# Altium schematic sheet Gatekeeper_sheet4_power_supply_V2.2.SchDoc(Gatekeeper_sheet4_power_supply_V2.2)
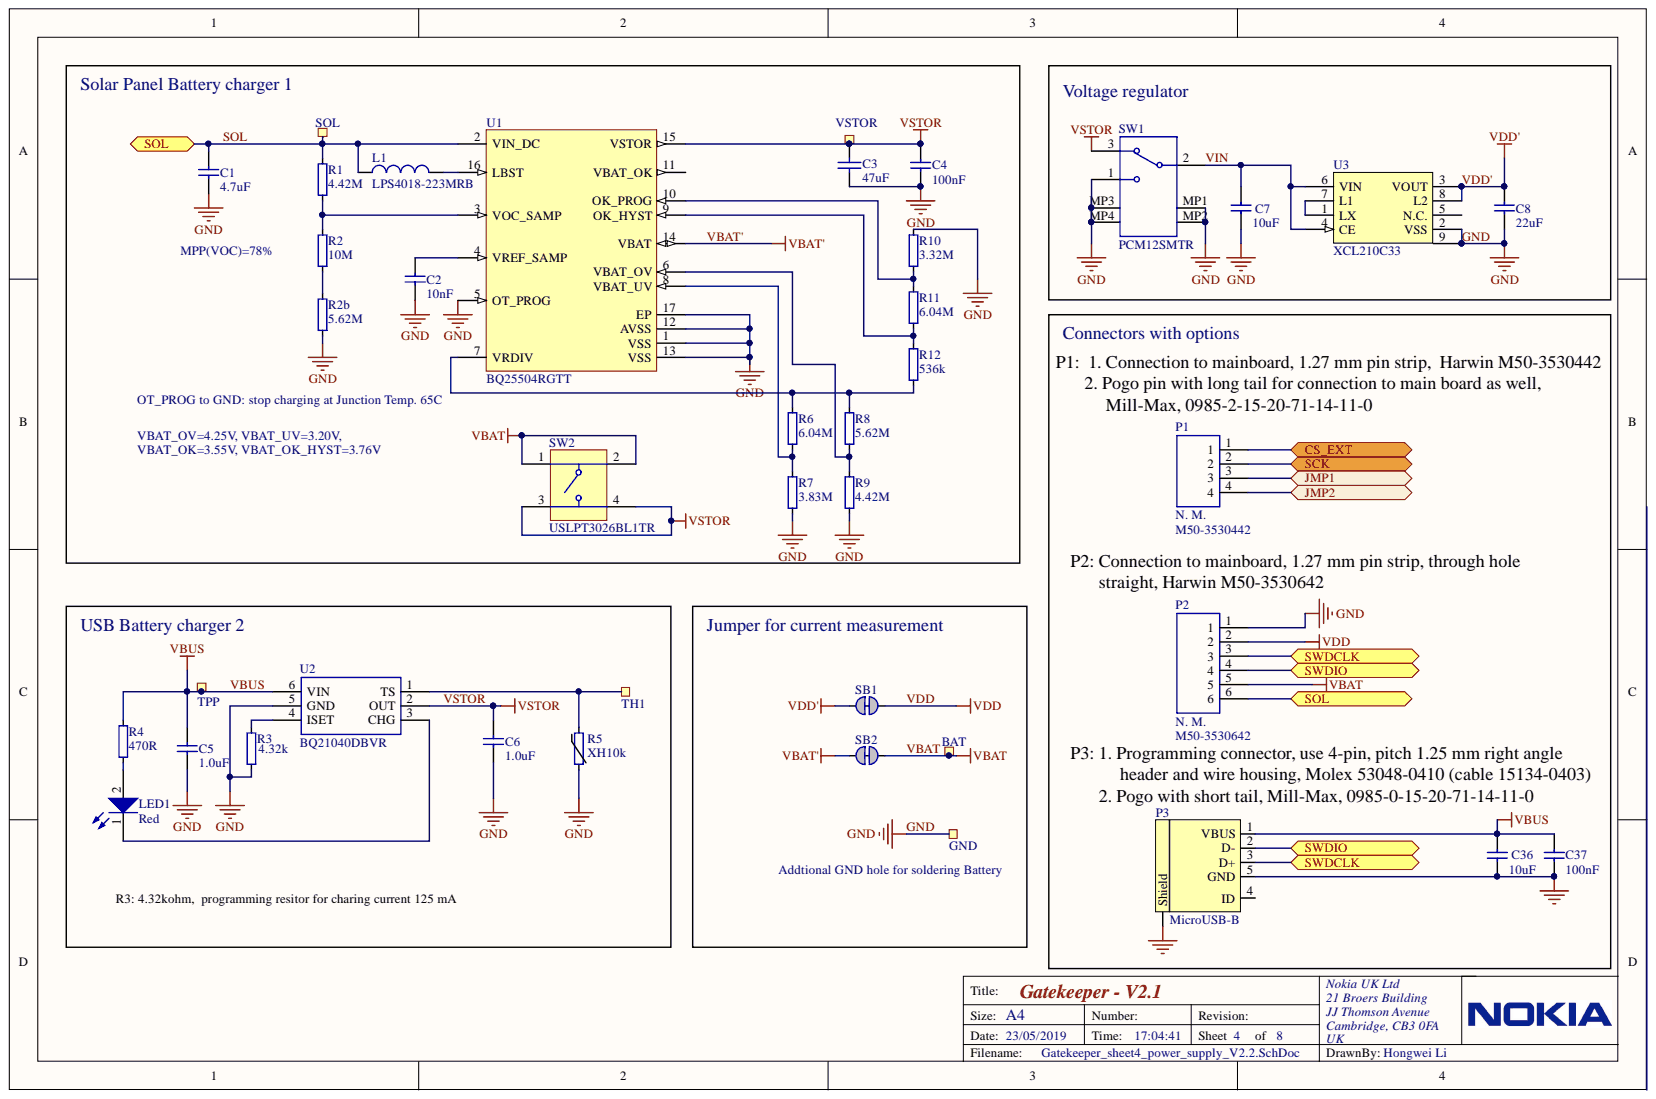
[diagram p0: Gatekeeper_sheet4_power_supply_V2.2.SchDoc]

## Parts
BAT  Comment  [TH_SINGLE]  (value not drawn)  (no BOM)
C1  4.7uF  [CAPC1608X06L]
C2  10nF  [CAPC1005X04L]
C3  47uF  [CAPC2013X145X20NL20]
C4  100nF  [CAPC1005X04L]
C5  1.0uF  [CAPC1608X06L]
C6  1.0uF  [CAPC1608X06L]
C7  10uF  [CAPC1608X06L]
C8  22uF  [CAPC1608X06L]
C36  10uF  [CAPC1608X06L]
C37  100nF  [CAPC1005X04L]
GND  Comment  [TH_SINGLE_SQUARE]  (value not drawn)  (no BOM)
L1  LPS4018-223MRB  [COIL-LPS4018_V]
LED1  Red  [0603_LED]
P1  N. M.  value M50-3530442  [HDRV4W45P0X127_1X4_508X210X430P]  (no BOM)
P2  N. M.  value M50-3530642  [HDRV6W45P0X127_1X6_762X210X430P]  (no BOM)
P3  MicroUSB-B  [USB-Micro-B-RA-SMD-PiP]
R1  4.42M  [RESC1005X04L]
R2  10M  [RESC1005X04L]
R2b  5.62M  [RESC1005X04L]
R3  4.32k  [RESC1005X04L]
R4  470R  [RESC1005X04L]
R5  XH10k  [RESC1005X04L_overlay2]
R6  6.04M  [RESC1005X04L]
R7  3.83M  [RESC1005X04L]
R8  5.62M  [RESC1005X04L]
R9  4.42M  [RESC1005X04L]
R10  3.32M  [RESC1005X04L]
R11  6.04M  [RESC1005X04L]
R12  536k  [RESC1005X04L]
SB1  Solderbridge  [Solderbridge_Shorted_Big]  (value not drawn)
SB2  Solderbridge  [Solderbridge_Shorted_Big]  (value not drawn)
SOL  Comment  [List_1x1_small-NC]  (value not drawn)  (no BOM)
SW1  PCM12SMTR  [SWITCH_CKCP-PCM12SMTR_V]
SW2  USLPT3026BL1TR  [Switch_USLPT3026EL1TR]
TH1  =Value  [TP_SMD_Ø1.2mm]  (no BOM)
TPP  VBUS  [TP_SMD_Ø1.5mm]  (no BOM)
U1  BQ25504RGTT  [RGT0016C_V]
U2  BQ21040DBVR  [SOT95P280X145-6N]
U3  XCL210C33  [CL-2025]
VSTOR  =Value  [List_1x1_small-NC]  (no BOM)

## Ports
CS_EXT, JMP1, JMP2, SCK, SOL, SWDCLK, SWDIO

## Nets
CS_EXT: P1.1 ; port CS_EXT
GND: C1.2 C2.2 C3.1 C4.2 C5.1 C6.1 C7.1 C8.1 C36.1 C37.2 GND.1 P2.1 P3.5(GND) P3.6(Shield) R2b.2 R3.2 R5.2 R7.2 R9.2 R10.2 SW1.1 SW1.MP1 SW1.MP2 SW1.MP3 SW1.MP4 U1.1(VSS) U1.5(OT_PROG) U1.12(AVSS) U1.13(VSS) U1.17(EP) U2.5(GND) U3.2(VSS) U3.9
JMP1: P1.3 ; port JMP1
JMP2: P1.4 ; port JMP2
SCK: P1.2 ; port SCK
SOL: C1.1 L1.1 P2.6 R1.1 SOL.1 U1.2(VIN_DC) ; port SOL
SWDCLK: P2.3 P3.3(D+) ; port SWDCLK
SWDIO: P2.4 P3.2(D-) ; port SWDIO
VBAT: BAT.1 P2.5 SB2.1 SW2.1 SW2.2
VBAT': SB2.2 U1.14(VBAT)
VBUS: C5.2 C36.2 C37.1 P3.1(VBUS) R4.1 TPP.1 U2.6(VIN)
VDD: P2.2 SB1.1
VDD': C8.2 SB1.2 U3.3(VOUT) U3.8(L2)
VIN: C7.2 SW1.2 U3.4(CE) U3.6(VIN)
VSTOR: C3.2 C4.1 C6.2 SW1.3 SW2.3 SW2.4 U1.15(VSTOR) U2.2(OUT) VSTOR.1
NetC2_1: C2.1 U1.4(VREF_SAMP)
NetL1_2: L1.2 U1.16(LBST)
NetLED1_1: LED1.1 U2.3(CHG)
NetLED1_2: LED1.2 R4.2
NetR1_2: R1.2 R2.1 U1.3(VOC_SAMP)
NetR2_2: R2.2 R2b.1
NetR3_1: R3.1 U2.4(ISET)
NetR5_1: R5.1 TH1.1 U2.1(TS)
NetR6_1: R6.1 R8.1 R12.2 U1.7(VRDIV)
NetR6_2: R6.2 R7.1 U1.8(VBAT_UV)
NetR8_2: R8.2 R9.1 U1.6(VBAT_OV)
NetR10_1: R10.1 R11.1 U1.10(OK_PROG)
NetR11_2: R11.2 R12.1 U1.9(OK_HYST)
NetU3_1: U3.1(LX) U3.7(L1)
N00082:

Not connected: P3 4(ID); U1 11(VBAT_OK); U3 5(N.C.)

## Library data of the parts
BAT GND SOL TH1 TPP VSTOR = TP_SMD: SMD testpad of various size; Library Name: Gatekeeper_V2.1.SchLib
C1 = CAP_0603: Capacitor, 0603, X5R, ±10%; Part Number: C0603-4µ7; Manufacturer Part Number: GCJ188C70J475KE02D; Manufacturer: Murata Electronics; 2nd source part number: 490-16480-1-ND; Note: 6.3V; Value: 4.7uF; Supplier part number: 81-GCJ188C70J475KE2D; Supplier: Mouser; Pin Count: 2; Footprint Doc: 0603; Component Kind: Standard; 2nd source Supplier: DigiKey; Library Name: Gatekeeper_V2.1.SCHLIB
C2 = CAP_0402_1: Capacitor, 0402, X7R, ±5%; Part Number: C0402; Manufacturer Part Number: CC402JRX7R9BB103; Manufacturer: Yageo; 2nd source part number: 311-3339-1-ND; Note: 50V; Value: 10nF; Supplier part number: 603-CC402JRX7R9BB103; Supplier: Mouser; Pin Count: 2; Footprint Doc: 0402; Datasheet: https://www.mouser.co.uk/datasheet/2/447/UPY-GPHC_X7R_6.3V-to-50V_18-1154002.pdf; Component Kind: Standard; 2nd source Supplier: DigiKey; Library Name: Gatekeeper_V2.1.SCHLIB
C3 = CMP-2000-05451-1: Chip Capacitor, 47 uF, +/- 20%, 10 V, -55 to 85 degC, 0805 (2012 Metric), RoHS, Tape and Reel; PCN Part Number: MLCCPartNumberChange30/Nov/2012; Manufacturer: TDK; Manufacture Part Number: C2012X5r1A476M125AC; Voltage - Rated: 10V; Temperature Coefficient: X5R; Product Training Modules: HighCapacitanceReplacementCSeriesGeneralApplicationsSEAT,CCV,andTVCLDesignToolsGuidetoReplacinganElectrolyticCapacitorwithanMLCC; Other Names: 445-8239-1; Online Catalog: CSeries,GeneralPurpose; Kits: 445-9015-KIT-ND-KITMLCC1000PF-47UF080510-50V445-9003-KIT-ND-KITCAPCERHICAPMLCCSMD; Catalog Drawings: CSERIES_2012-0805_1.45; Capacitance: 47µF; Value: 47uF; Tolerance: ±20%; Thickness (Max): 0.057"(1.45mm); Standard Package: 1; Size / Dimension: 0.079"Lx0.049"W(2.00mmx1.25mm); Series: C; RoHS Information: RoHSCertificate-MLCC; Ratings: -; Packaging: CutTape(CT); Package URL: https://product.tdk.com/info/zh/catalog/datasheets/mlcc_commercial_general_zh.pdf; Package / Case: 0805(2012Metric); Operating Temperature: -55degC~85degC; Mounting Type: SurfaceMount,MLCC; Manufacturer URL: http://www.tdk.com/; Lead Style: -; Lead Spacing: -; Height - Seated (Max): -; Features: -; Family: CeramicCapacitors; Datasheet Version: Mar-15; Datasheet URL: http://download.siliconexpert.com/pdfs/2015/4/21/15/54/45/779/tdk_/manual/37024850441143360mlcc_commercial_general_en.pdf; Component Kind: Standard; Category: Capacitors; Applications: GeneralPurpose; Library Name: Gatekeeper_V2.1.SchLib
C4 C37 = (no symbol name): Capacitor, 0402, X7R, ±10%; Part Number: C0402; Manufacturer Part Number: 885012205037; Manufacturer: Wurth Electronics; 2nd source part number: 81-GCM155R71C104KA5D; Note: 16V; Value: 100nF; Supplier part number: 710-885012205037; Supplier: Mouser; Pin Count: 2; Footprint Doc: 0402; Datasheet: https://www.mouser.co.uk/datasheet/2/445/885012205037-554188.pdf; Component Kind: Standard; 2nd source Supplier: Mouser; Library Name: Gatekeeper.SCHLIB
C5 C6 = (no symbol name): Capacitor, 0603, X7R, ±10%; Part Number: C0603-1µ0; Manufacturer Part Number: JMK107B7105KA-T; Manufacturer: Taiyo Yuden; 2nd source part number: 710-885012206002; Note: 6.3V; Value: 1.0uF; Supplier part number: 963-JMK107B7105KA-T; Supplier: Mouser; Pin Count: 2; Footprint Doc: 0603; Component Kind: Standard; 2nd source Supplier: Mouser; Library Name: Gatekeeper.SCHLIB
C7 C36 = (no symbol name): Capacitor, 0603, X5R, ±10%; Manufacturer Part Number: C1608X5R1A106K080AC; Manufacturer: TDK; 2nd source part number: 81-GRM188R61A106KE9J; Note: 10V; Value: 10uF; Supplier part number: 810-C1608X5R1A106K; Supplier: Mouser; Pin Count: 2; Footprint Doc: 0603; Datasheet: https://www.mouser.co.uk/datasheet/2/400/lcc_commercial_general_en-837201.pdf; Component Kind: Standard; 2nd source Supplier: Mouser; Library Name: Gatekeeper.SCHLIB
C8 = (no symbol name): Capacitor, 0603, X5R, ±20%; Manufacturer Part Number: C1608X5R1A226M080AC; Manufacturer: TDK; 2nd source part number: 81-GRM188R61A226ME5J; Note: 10V; Value: 22uF; Supplier part number: 810-C1608X5R1A226M; Supplier: Mouser; Pin Count: 2; Footprint Doc: 0603; Datasheet: https://www.mouser.co.uk/datasheet/2/400/lcc_commercial_general_en-837201.pdf; Component Kind: Standard; 2nd source Supplier: Mouser; Library Name: Gatekeeper.SCHLIB
L1 = LPS4018-223MRB: Shielded Power Inductor, 22 uH, +/- 20%, 360 mOhm, -40 to 85 degC, 2-Pin SMD, RoHS, Tape and Reel; Manufacturer: Coilcraft; Unit Weight: 0.001411oz; Test Frequency: 100kHz; Self Resonant Frequency: 26MHz; Qualification: AEC-Q200; Maximum DC Resistance: 360mOhms; Maximum DC Current: 830mA; Inductance: 22uH; Core Material: Ferrite; Width: 3mm; Type: ShieldedPowerInductor; Tolerance: 20%; Termination Style: SMD/SMT; Shielding: Shielded; Series: LPS3015; RoHS: True; Product Category: FixedInductors; Product: PowerInductors; Packaging: CutTape; Package Reference: LPS4018; Package Description: 2-Pin Surfave Mount Device, Body 2.95 x 2.95 mm; Operating Temperature Range: -40Cto+85C; Mounting Technology: SurfaceMount; Mounting Style: SMD/SMT; Manufacturer URL: http://www.coilcraft.com/; Manufacture Part Number: LPS4018-223MRB; Length: 4.0mm; Height: 1.7mm; Factory Pack Quantity: 1; Datasheet URL: http://www.coilcraft.com/pdfs/lps4018.pdf; Component Kind: Standard; Brand: Coilcraft; Library Name: Gatekeeper_V2.1.SchLib
LED1 = CMP-1426-00001-1: SMD mono-color Chip LED, WL-SMCW, Red; Manufacturer Part Number: 150060RS75000; Manufacturer: Wuerth Elektronik; Supplier Part Number: 710-150060RS75000; Supplier: Mouser; PackageReference: 0603_A; Library Name: Integrated_Library - 0603_LED.IntLib; ComponentLink2URL: http://katalog.we-online.com/led/datasheet/150060RS75000.pdf; ComponentLink2Description: Datasheet; ComponentLink1URL: http://www.we-online.com; ComponentLink1Description: Manufacturer URL; Component Kind: Standard; Color: Red
P1 = M50-3530442: Headers & Wire Housings 4 WAY SIL VERT PC TAIL P/HDR; Manufacturer: HARWIN; Geometry.Height: 4.3mm; Assembly: Not Mounted; Supplier Part Number: 855-M50-3530442; Supplier: Mouser; Mouser Price/Stock: https://www.mouser.com/Search/Refine.aspx?Keyword=855-M50-3530442; Mouser Part Number: 855-M50-3530442; Manufacturer Part Number: M50-3530442; Library Name: M50-3530x42.SchLib; Datasheet Link: https://componentsearchengine.com/Datasheets/1/M50-3530442.pdf
P2 = M50-3530642: Headers & Wire Housings 6 WAY SIL VERT PC TAIL P/HDR; Manufacturer: HARWIN; Geometry.Height: 4.3mm; Assembly: Not Mounted; Supplier Part Number: 855-M50-3530642; Supplier: Mouser; Mouser Price/Stock: https://www.mouser.com/Search/Refine.aspx?Keyword=855-M50-3530642; Mouser Part Number: 855-M50-3530642; Manufacturer Part Number: M50-3530642; Library Name: M50-3530x42.SchLib; Datasheet Link: https://componentsearchengine.com/Datasheets/1/M50-3530642.pdf
P3 = USB-Micro-B-RA-SMD-PiP: MicroUSB-B-SMT; Manufacturer Part Number: AJU55-BS2111-L; Manufacturer: Allen Creations Corp.; 2nd source part number: 10118193-0001LF; Value: MicroUSB-B; Supplier part number: 649-10118193-0001LF; Supplier: Mouser; Pin Count: 5; Part Number: USB-Micro-B-RA-SMD-PiP; LatestRevisionDate: 2016-04-21; Footprint Doc: USB-Micro-B-RA-SMD-PiP; Datasheet: https://cdn.amphenol-icc.com/media/wysiwyg/files/documentation/datasheet/inputoutput/io_usb_micro.pdf; Component Kind: Standard; 2nd source Supplier: Digi-Key; Library Name: Gatekeeper_8D_V2.1.PcbLib
R1 R9 = (no symbol name): Resistor, 0402, ±1%, 0.063W; Part Number: R0402; Manufacturer Part Number: RC0402FR-074M42L; Manufacturer: Yageo; Value Ohm: 4.42e6; Note: Chip Res 1%; Value: 4.42M; Supplier part number: 603-RC0402FR-074M42L; Supplier: Mouser; Pin Count: 2; Footprint Doc: 0402; Component Kind: Standard; Library Name: Gatekeeper.SCHLIB
R2 = (no symbol name): Resistor, 0402, ±1%, 0.063W; Part Number: R0402; Manufacturer Part Number: RC0402FR-0710ML; Manufacturer: Yageo; 2nd source part number: DigiKey; Value Ohm: 10e6; Note: Chip Res 1%; Value: 10M; Supplier part number: 603-RC0402FR-0710ML; Supplier: Mouser; Pin Count: 2; Footprint Doc: 0402; Datasheet: https://www.mouser.co.uk/datasheet/2/447/PYu-RC_Group_51_RoHS_L_10-1527934.pdf; Component Kind: Standard; 2nd source Supplier: 311-10.0MLRCT-ND; Library Name: Gatekeeper.SCHLIB
R2b R8 = (no symbol name): Resistor, 0402, ±1%, 0.063W; Part Number: R0402; Manufacturer Part Number: RC0402FR-075M62L; Manufacturer: Yageo; 2nd source part number: 71-CRCW04025M62FKED; Value Ohm: 5.62e6; Note: Chip Res 1%; Value: 5.62M; Supplier part number: 603-RC0402FR-075M62L; Supplier: Mouser; Pin Count: 2; Footprint Doc: 0402; Datasheet: https://www.mouser.co.uk/datasheet/2/447/PYu-RC_Group_51_RoHS_L_10-1527934.pdf; Component Kind: Standard; 2nd source Supplier: Mouser; Library Name: Gatekeeper.SCHLIB
R3 = RES_0402: Resistor, 0402, ±1%, 0.063W; Part Number: R0402; Manufacturer Part Number: CRCW04024K32FKED; Manufacturer: Vishay; Value Ohm: 4320; Note: Chip Res 1%; Value: 4.32k; Supplier part number: 71-CRCW0402-4.32K-E3; Supplier: Mouser; Pin Count: 2; Footprint Doc: 0402; Datasheet: https://www.mouser.co.uk/datasheet/2/427/dcrcwe3-45987.pdf; Component Kind: Standard; Library Name: Gatekeeper_V2.1.SCHLIB
R4 = RES_1: Resistor, ±1%, 0.063W; Part number IPS: 00000007975; Part Number: R0402-470; Manufacturer Part Number: CRCW0402470RFKEDHP; Manufacturer: Vishay; 2nd source part number: 1738845; Value Ohm: 470; Note: Chip Res 1%; Value: 470R; Supplier part number: 71-CRCW0402470RFKEDH; Supplier: Mouser; Published: 2009-05-08; Pin Count: 2; Footprint Doc: 0402; Datasheet: https://www.mouser.co.uk/datasheet/2/427/crcwhpe3-524687.pdf; Component Kind: Standard; 2nd source Supplier: Farnell; Library Name: Gatekeeper_V2.1.SCHLIB
R5 = NCP15XH103F03RC: THERMISTOR 10K OHM NTC 0402 SMD; Part number IPS: 00000007808; Manufacturer: Murata; 3rd source part number: 490-4801-1-ND; 2nd source part number: 2456121; Value Ohm: 10000; Note: Chip Res 1%; Database Table Name: Resistors$; Value: XH10k; Supplier Part Number: 81-NCP15XH103F03RC; Supplier: Mouser; Pin Count: 2; Part Number: NCP15XH103F03RC; Manufacturer Part Number: NCP15XH103F03RC; Library Name: Nordic Passive DB.DbLib; LatestRevisionDate: 2015-03-25; Footprint Doc: 0402; Datasheet: https://www.mouser.co.uk/datasheet/2/281/r44e-522712.pdf; Component Kind: Standard; 3rd source Supplier: Digi-Key; 2nd source Supplier: Farnell
R6 R11 = (no symbol name): Resistor, 0402, ±1%, 0.063W; Part Number: R0402; Manufacturer Part Number: RC0402FR-076M04L; Manufacturer: Yageo; 2nd source part number: 71-CRCW04026M04FKED; Value Ohm: 6.04e6; Note: Chip Res 1%; Value: 6.04M; Supplier part number: 603-RC0402FR-076M04L; Supplier: Mouser; Pin Count: 2; Footprint Doc: 0402; Datasheet: https://www.mouser.co.uk/datasheet/2/447/PYu-RC_Group_51_RoHS_L_10-1527934.pdf; Component Kind: Standard; 2nd source Supplier: Mouser; Library Name: Gatekeeper.SCHLIB
R7 = (no symbol name): Resistor, 0402, ±1%, 0.063W; Part Number: R0402; Manufacturer Part Number: CRCW04023M83FKED; Manufacturer: Vishay; 2nd source part number: 2316678; Value Ohm: 3.83e6; Note: Chip Res 1%; Value: 3.83M; Supplier part number: 71-CRCW04023M83FKED; Supplier: Mouser; Pin Count: 2; Footprint Doc: 0402; Datasheet: https://www.mouser.co.uk/datasheet/2/427/dcrcwe3-45987.pdf; Component Kind: Standard; 2nd source Supplier: Farnell; Library Name: Gatekeeper.SCHLIB
R10 = (no symbol name): Resistor, 0402, ±1%, 0.063W; Part Number: R0402; Manufacturer Part Number: RC0402FR-073M32L; Manufacturer: Yageo; 2nd source part number: 71-CRCW04023M32FKED; Value Ohm: 3.32e6; Note: Chip Res 1%; Value: 3.32M; Supplier part number: 603-RC0402FR-073M32L; Supplier: Mouser; Pin Count: 2; Footprint Doc: 0402; Datasheet: https://www.mouser.co.uk/datasheet/2/447/PYu-RC_Group_51_RoHS_L_10-1527934.pdf; Component Kind: Standard; 2nd source Supplier: Mouser; Library Name: Gatekeeper.SCHLIB
R12 = (no symbol name): Resistor, 0402, ±1%, 0.063W; Part Number: R0402; Manufacturer Part Number: ERJ-2RKF5363X; Manufacturer: Panasonic; 2nd source part number: 2302922; Value Ohm: 536000; Note: Chip Res 1%; Value: 536k; Supplier part number: 667-ERJ-2RKF5363X; Supplier: Mouser; Pin Count: 2; Footprint Doc: 0402; Datasheet: https://www.mouser.co.uk/datasheet/2/315/AOA0000C304-1149620.pdf; Component Kind: Standard; 2nd source Supplier: Farnell; Library Name: Gatekeeper.SCHLIB
SB1 SB2 = SOLDERBRIDGE_SHORTED: Shorted Solderbridge; Part Number: Solderbridge Shorted; Artikkelnr Simpro: N.A.; Value: Solderbridge; Published: 2012-08-07; Pin Count: 2; LatestRevisionDate: 2012-08-07; Footprint Doc: Solderbridge_Shorted; Component Kind: Net Tie; Artikkelnr Noca: N.A.; Publisher: Nordic Semiconductor; Library Name: Gatekeeper_V2.1.SCHLIB
SW1 = CMP-2000-06884-1: Ultraminiature Slide Switch, SPDT, 0.3 A, 6 V, -20 to 85 degC, 3-Pin SMD, RoHS, Tape and Reel; Manufacturer: C&K Components; Switch Operation: On-On; Contact Current-Max: 0.3A; Termination Style: RightAngle/SurfaceMount; Package Reference: PCM12SMTR; Package Description: 3-Pin Surface Mount Device, Body 6.7 x 2.6 mm; Mounting Technology: SurfaceMount; Manufacturer URL: http://www.ckswitches.com/; Datasheet Version: 02/2016; Datasheet URL: http://www.ck-components.com/media/1424/pcm.pdf; Contact Material: SilverOverCopper; Component Kind: Standard; Circuitry: SPDT; Library Name: Gatekeeper_V2.1.SCHLIB
SW2 = PushButton_LowProfile: Low-Profile Tactile Switch, SPST, 50 mA, 12 VDC, -40 to 85 degC, 4-Pin SMD, RoHS, Tape and Reel; Manufacturer: TE Connectivity; Switch Configuration: OFF - (ON); Operating Force: 100gf; Minimum Insulation Resistance: >=50MOhm; Mechanical Life: 100000Cycles; Maximum DC Voltage Rating: 12V; Maximum Contact Resistance: 500mOhm; Maximum AC Voltage Rating: 250VAC/1 minute; Dielectric Strength: >=250Vrms; Contact Rating @ Voltage: 500mA, 12VDC; Body Orientation: Straight; Actuator Style: Button; Actuator Length: 0.15mm; Type: Ultra Mini, Low Profile Tactile Swicthes; Supplier Part Number: 506-USLPT3026BL1TR; Supplier: Mouser; Seal Location: N/A; Seal Description: N/A; RoHS: Yes; Product Weight: N/A; Pole Throw Configuration: SPST; Part No: USLPT3026BL1TR; Packaging: TapeandReel; Package Reference: USLPT3026BL1TR; Package Description: 4-Pin Surface Mount Device, Body 3.0 x 2.6 mm; Operating Temperature: -40to85C; Mounting Technology: SurfaceMount; Mounting: SurfaceMount,GullWing; Manufacturer URL: https://www.te.com; Manufacture Part Numbrr: USLPT3026BL1TR; Housing Material: N/A; Family: USLPT; Electrical Life: N/A; Dimension: 3.0x2.6x0.45mm; Datasheet Version: 10/2018; Datasheet URL: https://www.te.com/commerce/DocumentDelivery/DDEController?Action=showdoc&DocId=Data+Sheet%7F2337227-1%7FA%7Fpdf%7FEnglish%7FENG_DS_2337227-1_A.pdf%7F2337227-1; Contact Plating: Silver; Component Kind: Standard; Actuator Color: N/A; Library Name: Gatekeeper_V2.1.SchLib
U1 = TI-BQ25504RGT16-1700X1700TP: Ultra Low Power Boost Converter with Battery Management for Energy Harvester App, 0.13 V, -40 to 85 degC, 16-pin QFN (RGT), Green (RoHS & no Sb/Br); Manufacturer Part Number: BQ25504RGTR; Manufacturer: Texas Instruments; Vin(Min)(V): 0.13; SubFamily: Battery Charge Management; Over Voltage Protection: N; Supplier Part Number: 595-BQ25504RGTR; Supplier: Mouser; PackageVersion: revH, Oct-2011; PackageReference: RGT0016C; PackageDescription: 16-Pin Plastic Quad Flat No-Lead, 3 x 3 mm Body, 0.5 mm Pitch, 1.7 x 1.7 mm Exposed Pad; NiMH: N; NiCd: N; Mounting Technology: Surface Mount; Li-Polymer: N; Li-Ion: N; Lead Acid: N; Eco-Plan: Green (RoHS & no Sb/Br); DatasheetVersion: SLUSAH0; ComponentLink1URL: http://www.ti.com/general/docs/lit/getliterature.tsp?genericPartNumber=BQ25504&fileType=pdf; ComponentLink1Description: Datasheet; Component Kind: Standard; Code_JEDEC: MO-220; Library Name: Gatekeeper_V2.1.SCHLIB
U2 = BQ21040DBVR: 0.8A Single Input Single-Cell Li-Ion and Li-Pol Battery Charger; Manufacturer: Texas Instruments; Geometry.Height: 1.45mm; Supplier Part Number: 595-BQ21040DBVR; Supplier: Mouser; Mouser Price/Stock: https://www.mouser.com/Search/Refine.aspx?Keyword=595-BQ21040DBVR; Manufacturer Part Number: BQ21040DBVR; Datasheet Link: http://www.ti.com/lit/gpn/bq21040; Component Kind: Standard; Library Name: Gatekeeper_V2.1.SchLib
U3 = XCL210: 3.3V 200mA Inductor Built-in Step-Down “micro DC/DC” Converter; Manufacturer Part Number: XCL210C331GR-G; Manufacturer: Torex Semiconductor; 2nd source part number: 893-1255-1-ND; Value: XCL210C33; Supplier part number: 865-XCL210C331GR-G; Supplier: Mouser; Pin Count: 8; LatestRevisionDate: 2017-01-30; Footprint Doc: CL-2025; Datasheet: https://www.torexsemi.com/file/xcl210/XCL210.pdf; Component Kind: Standard; 2nd source Supplier: DigiKey; Library Name: Gatekeeper.SchLib
